# Altium schematic sheet Sheet3.SchDoc
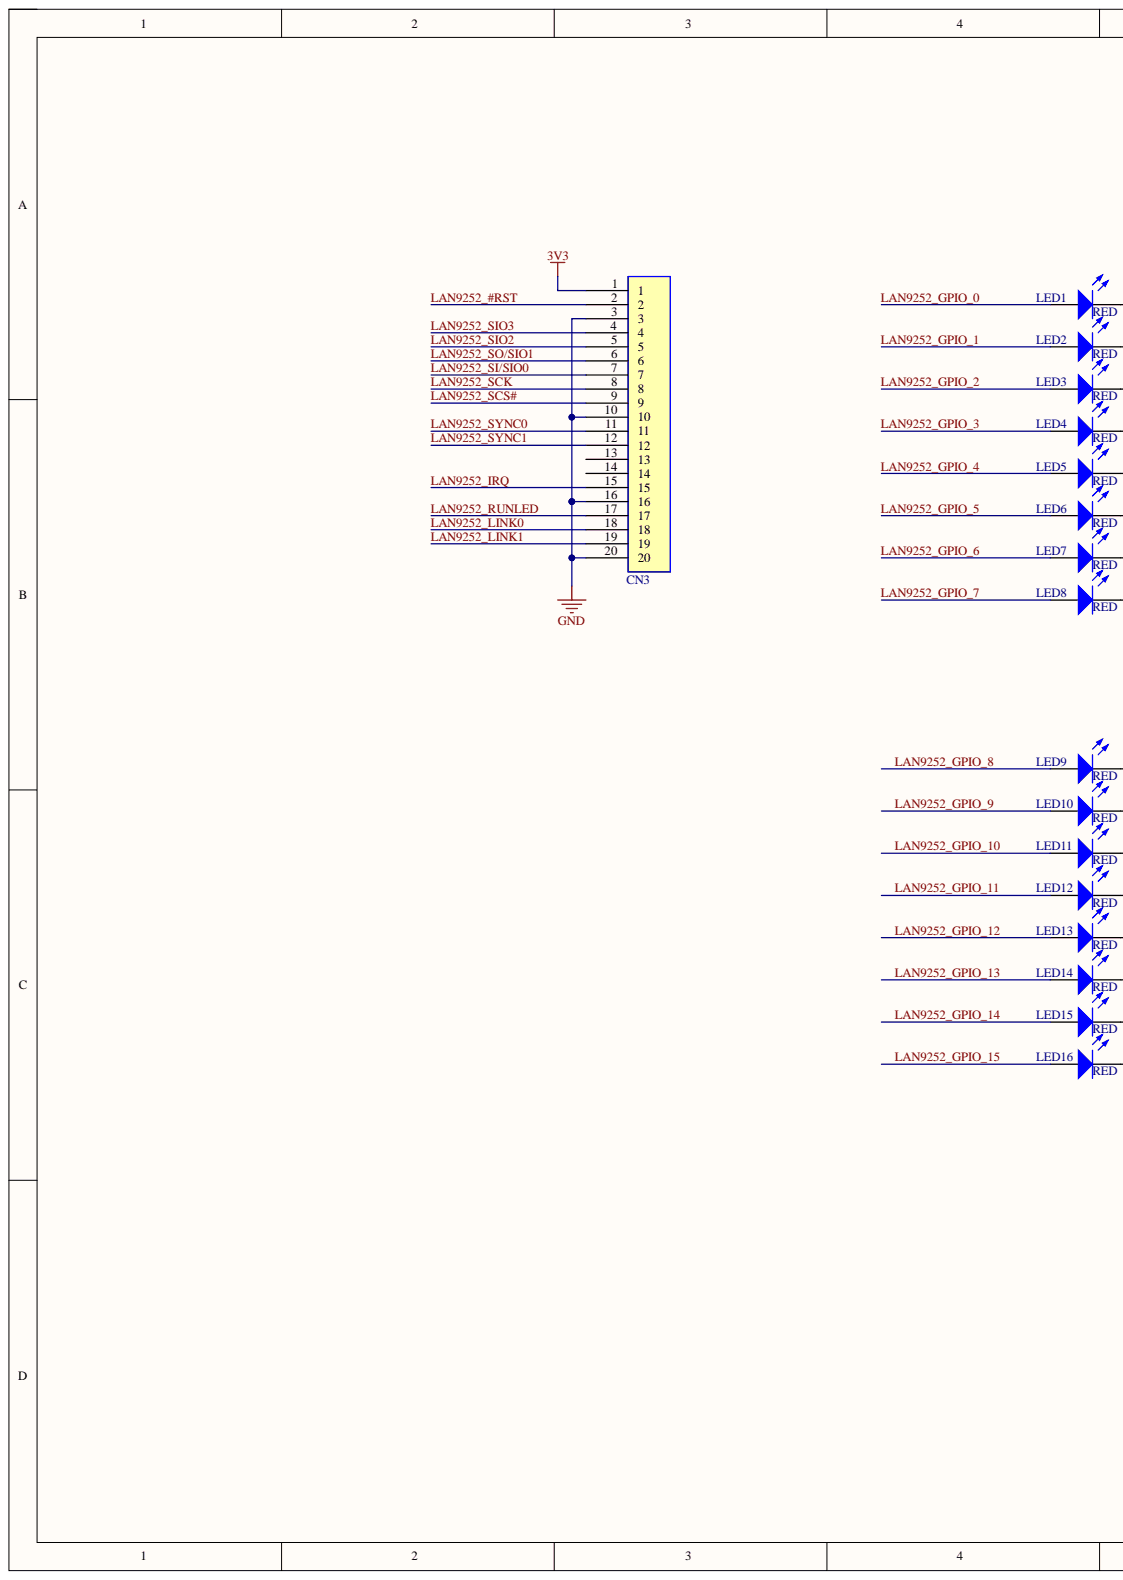
[diagram p0: Sheet3.SchDoc, left]
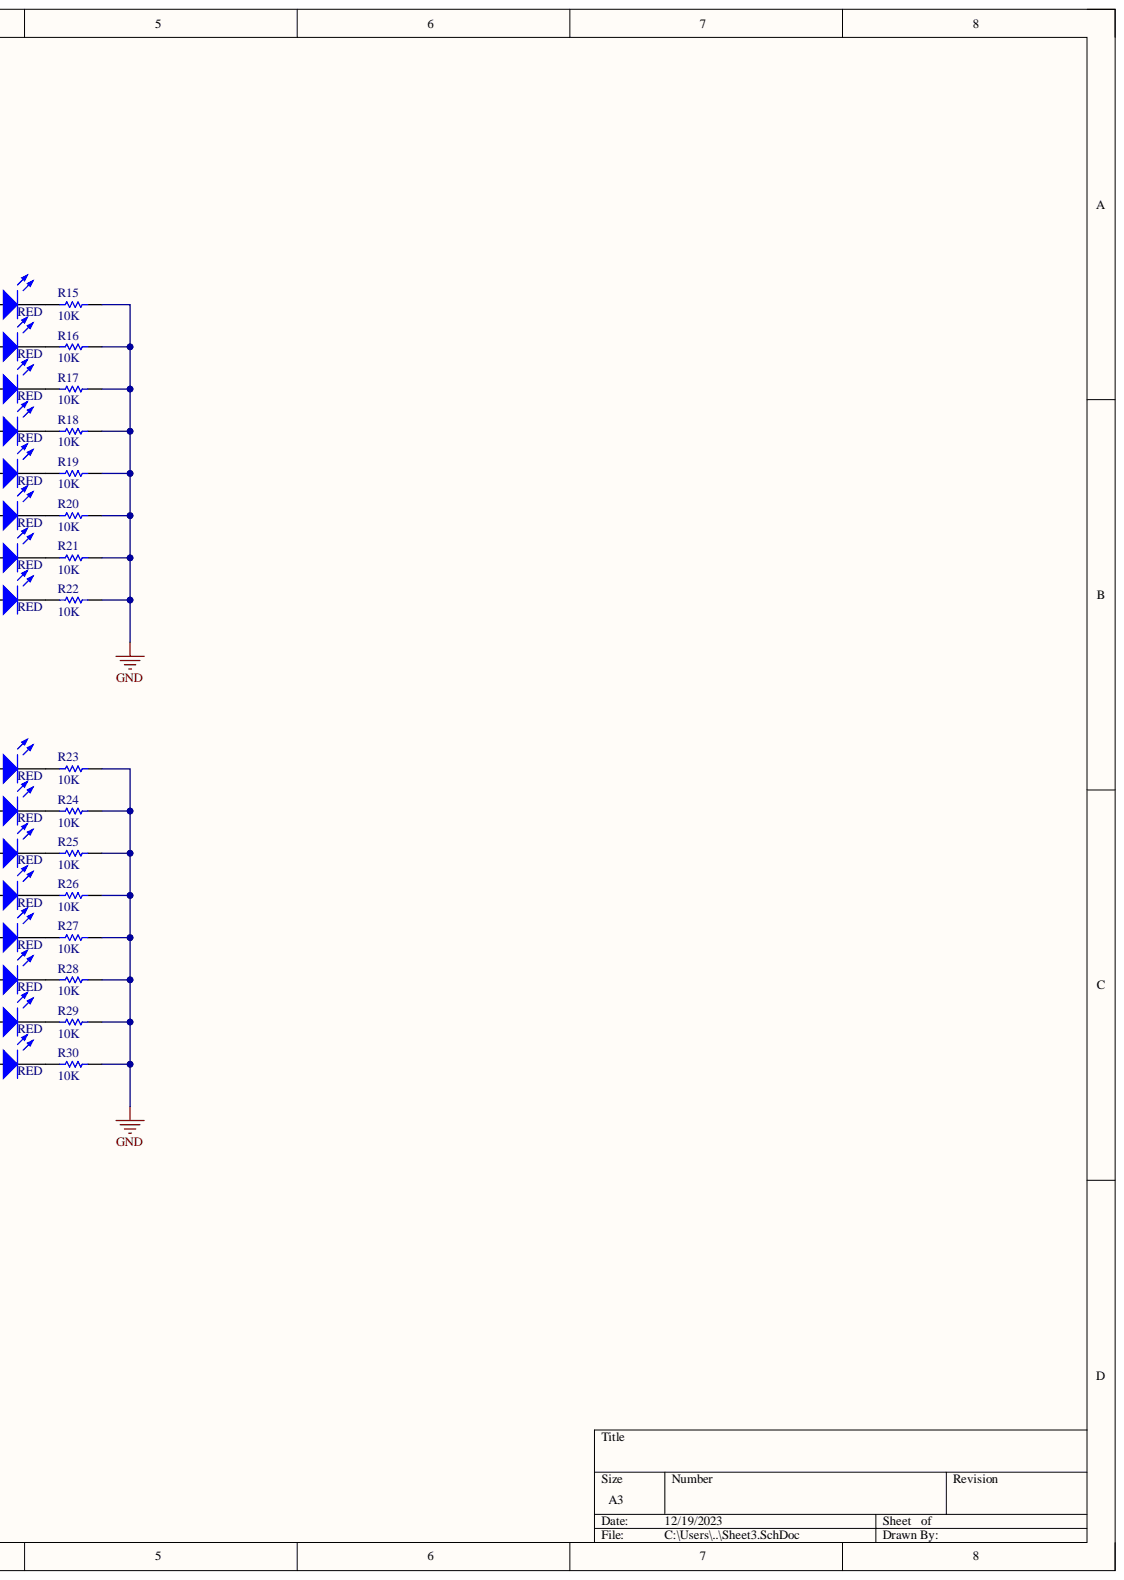
[diagram p1: Sheet3.SchDoc, right]

## Parts
CN3  PINHEAD_1x20  [PINHEAD_1x20_2.54mm]  (value not drawn)
LED1  value RED  [LED_1608]  (hidden comment: LED_1608)
LED2  value RED  [LED_1608]  (hidden comment: LED_1608)
LED3  value RED  [LED_1608]  (hidden comment: LED_1608)
LED4  value RED  [LED_1608]  (hidden comment: LED_1608)
LED5  value RED  [LED_1608]  (hidden comment: LED_1608)
LED6  value RED  [LED_1608]  (hidden comment: LED_1608)
LED7  value RED  [LED_1608]  (hidden comment: LED_1608)
LED8  value RED  [LED_1608]  (hidden comment: LED_1608)
LED9  value RED  [LED_1608]  (hidden comment: LED_1608)
LED10  value RED  [LED_1608]  (hidden comment: LED_1608)
LED11  value RED  [LED_1608]  (hidden comment: LED_1608)
LED12  value RED  [LED_1608]  (hidden comment: LED_1608)
LED13  value RED  [LED_1608]  (hidden comment: LED_1608)
LED14  value RED  [LED_1608]  (hidden comment: LED_1608)
LED15  value RED  [LED_1608]  (hidden comment: LED_1608)
LED16  value RED  [LED_1608]  (hidden comment: LED_1608)
R15  value 10K  [Resistance_1608]  (hidden comment: Resista_1608)
R16  value 10K  [Resistance_1608]  (hidden comment: Resista_1608)
R17  value 10K  [Resistance_1608]  (hidden comment: Resista_1608)
R18  value 10K  [Resistance_1608]  (hidden comment: Resista_1608)
R19  value 10K  [Resistance_1608]  (hidden comment: Resista_1608)
R20  value 10K  [Resistance_1608]  (hidden comment: Resista_1608)
R21  value 10K  [Resistance_1608]  (hidden comment: Resista_1608)
R22  value 10K  [Resistance_1608]  (hidden comment: Resista_1608)
R23  value 10K  [Resistance_1608]  (hidden comment: Resista_1608)
R24  value 10K  [Resistance_1608]  (hidden comment: Resista_1608)
R25  value 10K  [Resistance_1608]  (hidden comment: Resista_1608)
R26  value 10K  [Resistance_1608]  (hidden comment: Resista_1608)
R27  value 10K  [Resistance_1608]  (hidden comment: Resista_1608)
R28  value 10K  [Resistance_1608]  (hidden comment: Resista_1608)
R29  value 10K  [Resistance_1608]  (hidden comment: Resista_1608)
R30  value 10K  [Resistance_1608]  (hidden comment: Resista_1608)

## Nets
3V3: CN3.1
GND: CN3.3 CN3.10 CN3.16 CN3.20 R15.1 R16.1 R17.1 R18.1 R19.1 R20.1 R21.1 R22.1 R23.1 R24.1 R25.1 R26.1 R27.1 R28.1 R29.1 R30.1
LAN9252_#RST: CN3.2
LAN9252_GPIO_0: LED1.A
LAN9252_GPIO_1: LED2.A
LAN9252_GPIO_2: LED3.A
LAN9252_GPIO_3: LED4.A
LAN9252_GPIO_4: LED5.A
LAN9252_GPIO_5: LED6.A
LAN9252_GPIO_6: LED7.A
LAN9252_GPIO_7: LED8.A
LAN9252_GPIO_8: LED9.A
LAN9252_GPIO_9: LED10.A
LAN9252_GPIO_10: LED11.A
LAN9252_GPIO_11: LED12.A
LAN9252_GPIO_12: LED13.A
LAN9252_GPIO_13: LED14.A
LAN9252_GPIO_14: LED15.A
LAN9252_GPIO_15: LED16.A
LAN9252_IRQ: CN3.15
LAN9252_LINK0: CN3.18
LAN9252_LINK1: CN3.19
LAN9252_RUNLED: CN3.17
LAN9252_SCK: CN3.8
LAN9252_SCS#: CN3.9
LAN9252_SI/SIO0: CN3.7
LAN9252_SIO2: CN3.5
LAN9252_SIO3: CN3.4
LAN9252_SO/SIO1: CN3.6
LAN9252_SYNC0: CN3.11
LAN9252_SYNC1: CN3.12
NetLED1_C: LED1.C R15.2
NetLED2_C: LED2.C R16.2
NetLED3_C: LED3.C R17.2
NetLED4_C: LED4.C R18.2
NetLED5_C: LED5.C R19.2
NetLED6_C: LED6.C R20.2
NetLED7_C: LED7.C R21.2
NetLED8_C: LED8.C R22.2
NetLED9_C: LED9.C R23.2
NetLED10_C: LED10.C R24.2
NetLED11_C: LED11.C R25.2
NetLED12_C: LED12.C R26.2
NetLED13_C: LED13.C R27.2
NetLED14_C: LED14.C R28.2
NetLED15_C: LED15.C R29.2
NetLED16_C: LED16.C R30.2

Not connected: CN3 13 14

## Library data of the parts
CN3 = PINHEAD_1x20: Component Kind: Standard; Library Name: MyLaibrary.SchLib
LED1-LED16 = LED_1608: Component Kind: Standard; Library Name: MyLaibrary.SchLib
R15-R30 = Resista_1608: Component Kind: Standard; Library Name: MyLaibrary.SchLib
